# Altium schematic sheet LoRaB_Break_Board.SchDoc(LoRaB_Break_Board)
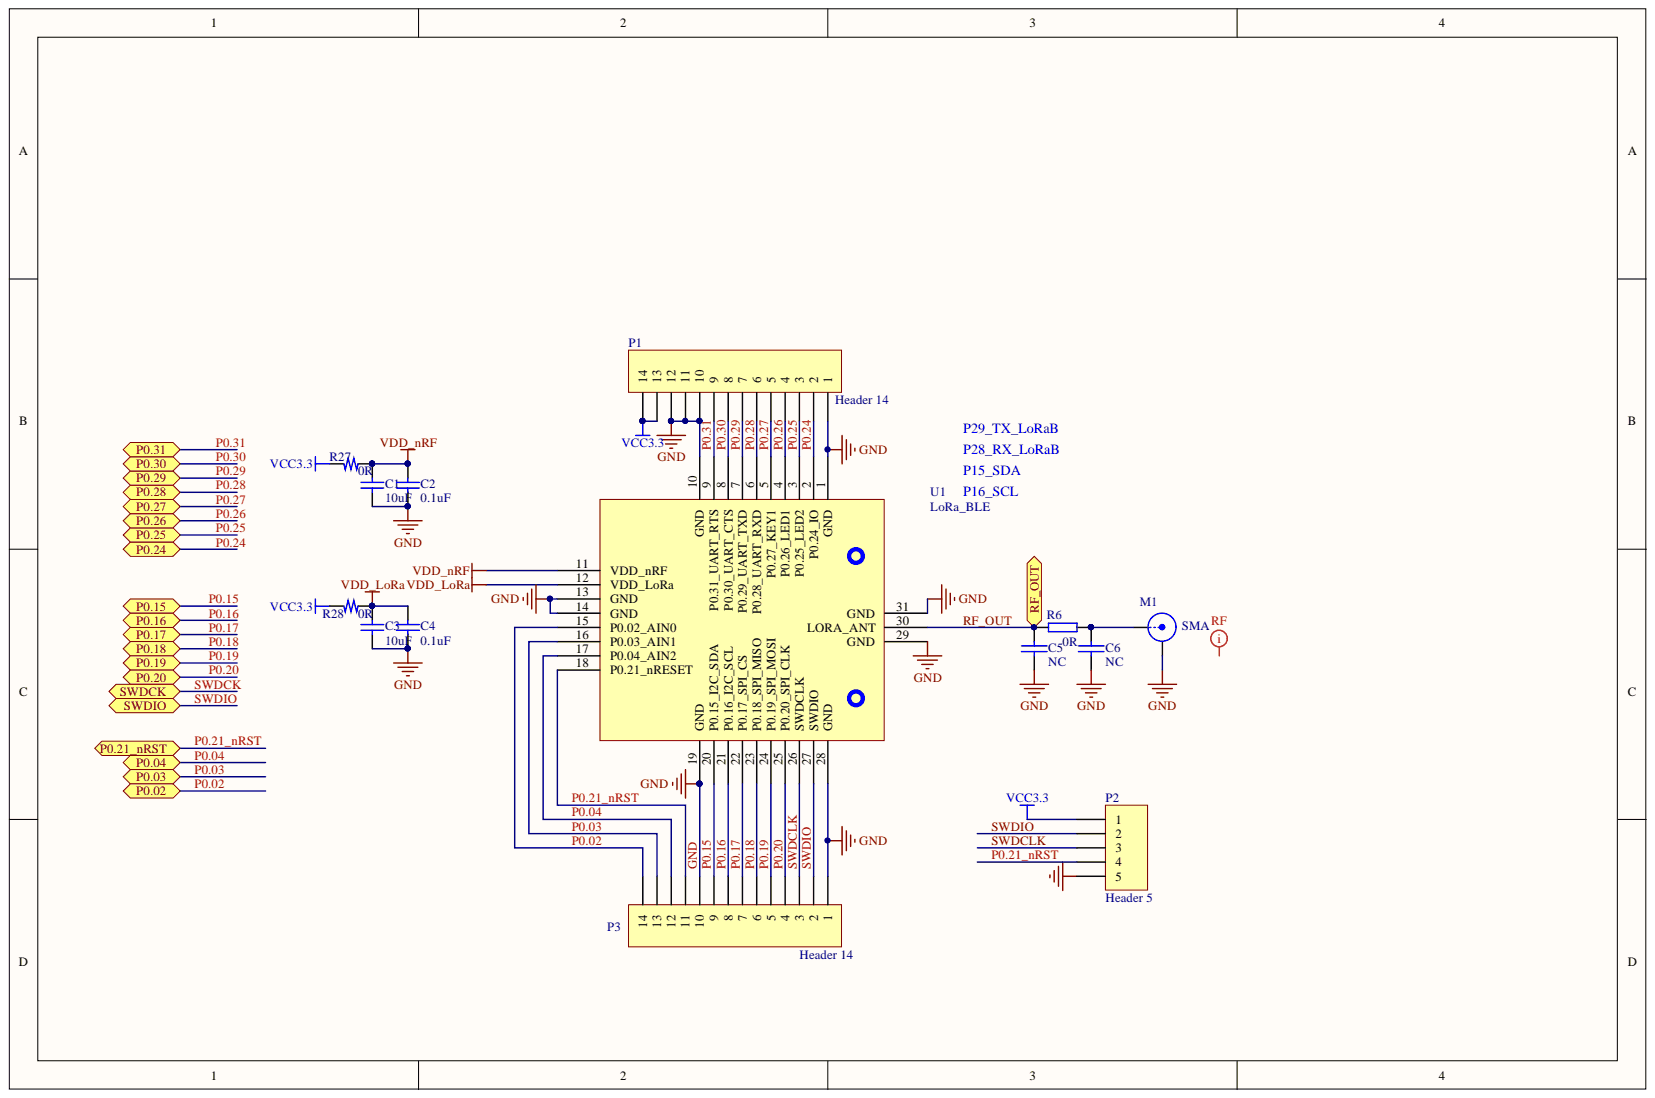
[diagram p0: LoRaB_Break_Board.SchDoc]

## Parts
C1  value 10uF  [CAPC1608X06L]  (hidden comment: Cap)
C2  value 0.1uF  [CAPC1005X04L]  (hidden comment: Cap)
C3  value 10uF  [CAPC1608X06L]  (hidden comment: Cap)
C4  value 0.1uF  [CAPC1005X04L]  (hidden comment: Cap)
C5  NC  [CAPC1005X04L]
C6  NC  [CAPC1005X04L]
M1  SMA  [SMA END LAUNCH JACK RECEPTACLE SHORT]
P1  Header 14  [HDR1X14]
P2  Header 5  [HDR1X5]
P3  Header 14  [HDR1X14]
R6  0R  [CAPC1005X04L]
R27  0R  [CAPC1608X06L]
R28  0R  [CAPC1608X06L]
U1  LoRa_BLE  [module_LoRa_BLE]

## Ports
P0.02, P0.03, P0.04, P0.15, P0.16, P0.17, P0.18, P0.19, P0.20, P0.21_NRST, P0.24, P0.25, P0.26, P0.27, P0.28, P0.29, P0.30, P0.31, RF_OUT, SWDCK, SWDIO

## Nets
VCC3.3: P1.13 P1.14 P2.1 R27.2 R28.2
VDD_LORA: C3.2 C4.2 R28.1 U1.12(VDD_LoRa)
VDD_NRF: C1.2 C2.2 R27.1 U1.11(VDD_nRF)
GND: C1.1 C2.1 C3.1 C4.1 C5.2 C6.2 M1.2 P1.1 P1.10 P1.11 P1.12 P2.5 P3.1 P3.10 U1.1(GND) U1.10(GND) U1.13(GND) U1.14(GND) U1.19(GND) U1.28(GND) U1.29(GND) U1.31(GND)
P0.02: P3.14 U1.15(P0.02_AIN0) ; port P0.02
P0.03: P3.13 U1.16(P0.03_AIN1) ; port P0.03
P0.04: P3.12 U1.17(P0.04_AIN2) ; port P0.04
P0.15: P3.9 U1.20(P0.15_I2C_SDA) ; port P0.15
P0.16: P3.8 U1.21(P0.16_I2C_SCL) ; port P0.16
P0.17: P3.7 U1.22(P0.17_SPI_CS) ; port P0.17
P0.18: P3.6 U1.23(P0.18_SPI_MISO) ; port P0.18
P0.19: P3.5 U1.24(P0.19_SPI_MOSI) ; port P0.19
P0.20: P3.4 U1.25(P0.20_SPI_CLK) ; port P0.20
P0.21_NRST: P2.4 P3.11 U1.18(P0.21_nRESET) ; port P0.21_NRST
P0.24: P1.2 U1.2(P0.24_IO) ; port P0.24
P0.25: P1.3 U1.3(P0.25_LED2) ; port P0.25
P0.26: P1.4 U1.4(P0.26_LED1) ; port P0.26
P0.27: P1.5 U1.5(P0.27_KEY1) ; port P0.27
P0.28: P1.6 U1.6(P0.28_UART_RXD) ; port P0.28
P0.29: P1.7 U1.7(P0.29_UART_TXD) ; port P0.29
P0.30: P1.8 U1.8(P0.30_UART_CTS) ; port P0.30
P0.31: P1.9 U1.9(P0.31_UART_RTS) ; port P0.31
RF_OUT: C5.1 R6.1 U1.30(LORA_ANT) ; port RF_OUT
SWDCLK: P2.3 P3.3 U1.26(SWDCLK)
SWDIO: P2.2 P3.2 U1.27(SWDIO) ; port SWDIO
NetC6_1: C6.1 M1.1 R6.2
N00073:
SWDCK: ; port SWDCK

## Library data of the parts
C1-C4 = Cap: Capacitor; Component Kind: Standard; LatestRevisionDate: 17-Jul-2002; LatestRevisionNote: Re-released for DXP Platform.; Library Name: Miscellaneous Devices.IntLib; PackageReference: RAD-0.3; Published: 8-Jun-2000; Signal Integrity: Cap; Simulation: CAP; Publisher: Altium Limited
C5 C6 = CAP: Capacitor; Component Kind: Standard; Library Name: 电阻容感类.SchLib
M1 = SMA: SMA Straight Connector; Component Kind: Standard; LatestRevisionDate: 05-oct-2007  -  Semtech; LatestRevisionNote: Re-released for DXP Platform.; Library Name: SX1276_e266v02a.SchLib; Publisher: Altium Limited
P1 P3 = Header 14: Header, 14-Pin; Component Kind: Standard; LatestRevisionDate: 17-Jul-2002; LatestRevisionNote: Re-released for DXP Platform.; Library Name: Miscellaneous Connectors.IntLib; Signal Integrity: Connector; Publisher: Altium Limited
P2 = Header 5: Header, 5-Pin; Component Kind: Standard; LatestRevisionDate: 17-Jul-2002; LatestRevisionNote: Re-released for DXP Platform.; Library Name: Miscellaneous Connectors.IntLib; Signal Integrity: Connector; Publisher: Altium Limited
R6 = RES: Component Kind: Standard; Library Name: 电阻容感类.SchLib
R27 R28 = 电阻: Component Kind: Standard
U1 = LoRa_BLE: Component Kind: Standard; Library Name: LORAB_BREAK_BOARD.SCHLIB
